# Altium schematic sheet ENCODER.SchDoc(ENCODER)
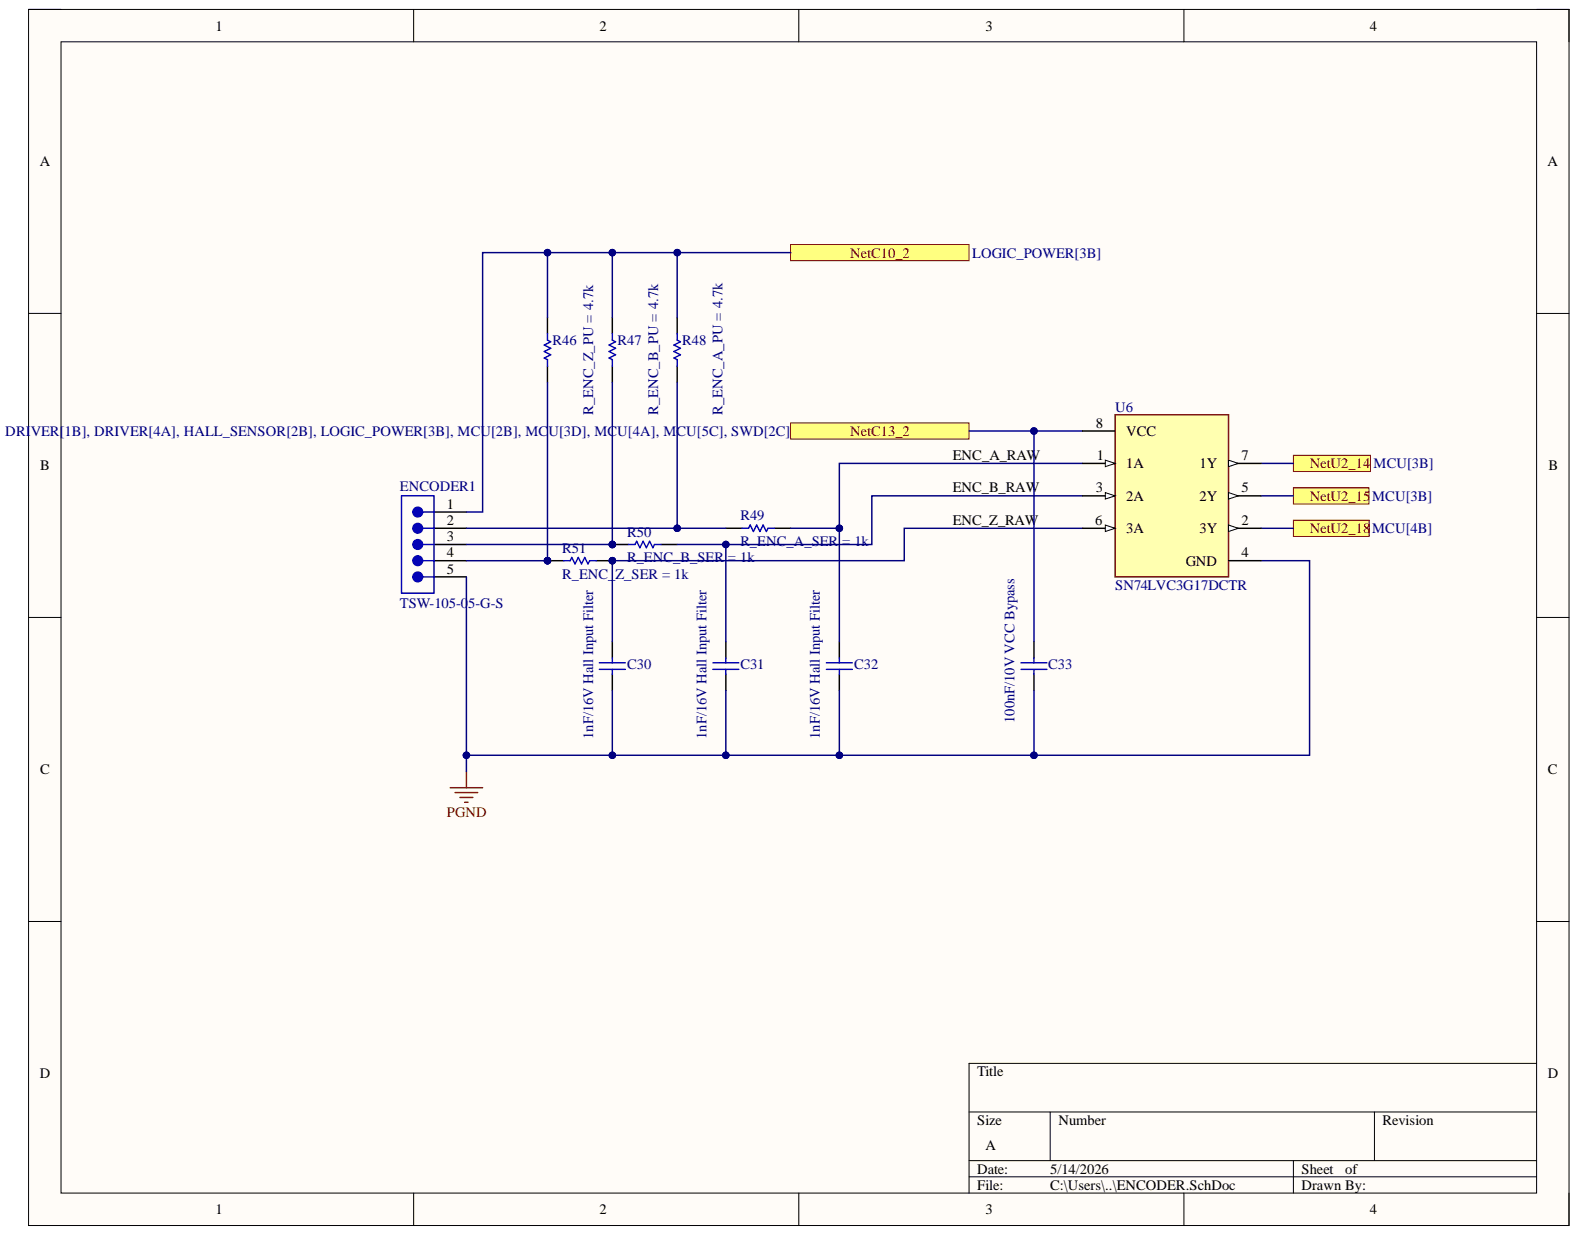
[diagram p0: ENCODER.SchDoc]

## Parts
C30  1nF/16V Hall Input Filter  [CAPC2013X145X50ML15T20]
C31  1nF/16V Hall Input Filter  [CAPC2013X145X50ML15T20]
C32  1nF/16V Hall Input Filter  [CAPC2013X145X50ML15T20]
C33  100nF/10V VCC Bypass  [CAPC0805(2012)100_N]
ENCODER1  TSW-105-05-G-S  [SMTC-TSW-105-05-X-S]
R46  R_ENC_Z_PU = 4.7k  [RESC3225X60X45NL20T20]
R47  R_ENC_B_PU = 4.7k  [RESC3225X60X45NL20T20]
R48  R_ENC_A_PU = 4.7k  [RESC3225X60X45NL20T20]
R49  R_ENC_A_SER = 1k  [RESC2013X50X30ML20T20]
R50  R_ENC_B_SER = 1k  [RESC2013X50X30ML20T20]
R51  R_ENC_Z_SER = 1k  [RESC2013X50X30ML20T20]
U6  SN74LVC3G17DCTR  [FP-DCT0008A-MFG]

## Ports
3.3V-LOGIC-SUPPLY, 5V- SUPPLY, ENC-A, ENC-B, ENC-C

## Nets
GND: C30.1 C31.1 C32.1 C33.1 ENCODER1.5 U6.4(GND)
3.3V-LOGIC-SUPPLY: C33.2 U6.8(VCC) ; port 3.3V-LOGIC-SUPPLY
5V- SUPPLY: ENCODER1.1 R46.2 R47.2 R48.2 ; port 5V- SUPPLY
ENC-A: U6.7(1Y) ; port ENC-A
ENC-B: U6.5(2Y) ; port ENC-B
ENC-C: U6.2(3Y) ; port ENC-C
ENC_A_RAW: C32.2 R49.2 U6.1(1A)
ENC_B_RAW: C31.2 R50.2 U6.3(2A)
ENC_Z_RAW: C30.2 R51.2 U6.6(3A)
NetENCODER1_2: ENCODER1.2 R48.1 R49.1
NetENCODER1_3: ENCODER1.3 R47.1 R50.1
NetENCODER1_4: ENCODER1.4 R46.1 R51.1

## Library data of the parts
C30-C32 = CMP-2007-01689-1: Manufacturer: Vitramon; Part Number: VJ0805Y102KXJCW1BC; Component Kind: Standard; Library Name: Altium Content Vault
C33 = CMP-1036-04396-1: CAP 100nF 10V ±20% 0805 (2012 Metric) Thickness 1mm SMD; Case-EIA: 0805; Case-Metric: 2012; Tolerance: Â±20%; Component Kind: Standard; Library Name: Altium Content Vault; Max Thickness: 1 mm; Rated Voltage: 10 V; Technology: SMT; Value: 100nF
ENCODER1 = CMP-1024-00363-1: 0.025" SQ Post Header, Through-hole, Vertical, -55 to 125 degC, 2.54 mm Pitch, 5-Pin, Male, RoHS; Manufacturer: Samtec; Pitch: 2.54 mm; Component Kind: Standard; ComponentLink1Description: Manufacturer URL; ComponentLink1URL: http://www.samtec.com; ComponentLink2Description: Datasheet; ComponentLink2URL: http://www.samtec.com/documents/webfiles/pdf/TSW_TH.PDF; ComponentLink3Description: Package Specification; ComponentLink3URL: http://www.samtec.com/documents/webfiles/cpdf/TSW-XXX-XX-XXX-X-XX-XXX-MKT.pdf; DatasheetVersion: F-214; Library Name: Altium Content Vault; Mounting Technology: Through-hole; Orientation: Vertical; PackageDescription: .025[0.64] SQ. Post Terminal Strip Assembly; PackageReference: TSW-105-05-X-S; PackageVersion: DM, 8/1988; PartNumber: TSW-105-05-G-S; RoHS: True; Temperature Range: -55 to 125 degC
R46-R48 = CMP-2005-00462-1: Manufacturer: Vishay Dale; Part Number: CRCW12104K70JNEAHP; Component Kind: Standard; Library Name: Altium Content Vault
R49-R51 = CMP-2001-04268-1: Manufacturer: Vishay Dale; Part Number: CRCW08051K00FKTC; Component Kind: Standard; Library Name: Altium Content Vault
U6 = CMP-0859-00525-5: IC BUFFER NON-INVERT 5.5V SM8; Manufacturer: Texas Instruments; Logic Function Desc: Non-Inverting; Min Supply Voltage: 1.65V; Nominal Supply Current: 10uA; Number Of Circuits: 3; Propagation Delay: 5.1ns; Standoff Height: 0mm; Ambient Temperature Range High: +125°C; Ambient Temperature Range Low: -40°C; Automotive: No; Category: IC; Component Kind: Standard; ComponentLink2Description: Datasheet; ComponentLink2URL: http://www.ti.com/lit/ds/symlink/sn74lvc3g17.pdf; Device Class L1: Integrated Circuits (ICs); Device Class L2: Logic ICs; Device Class L3: Buffers; Digikey Description: IC BUFFER NON-INVERT 5.5V SM8; Height: 1.3mm; Ipc Land Pattern Name: SOP65P400X130-8; Lead Free: Yes; Library Name: Altium Content Vault; Manufacturer Part Number: SN74LVC3G17DCTR; Max Supply Voltage: 5.5V; Mouser Description: Buffers & Line Drivers TRIPLE SCHMITT- TRIGGER BUFFER; Number Of Inputs: 3; Number Of Outputs: 3; Octopart: https://octopart.com/sn74lvc3g17dctr-texas+instruments-423176; Package: DCT0008A; RoHS: TRUE; Temperature: -40°C to +125°C; Temperature Range High: +125°C; Temperature Range Low: -40°C
